# Altium schematic sheet 02 ECG Amplifier.schdoc
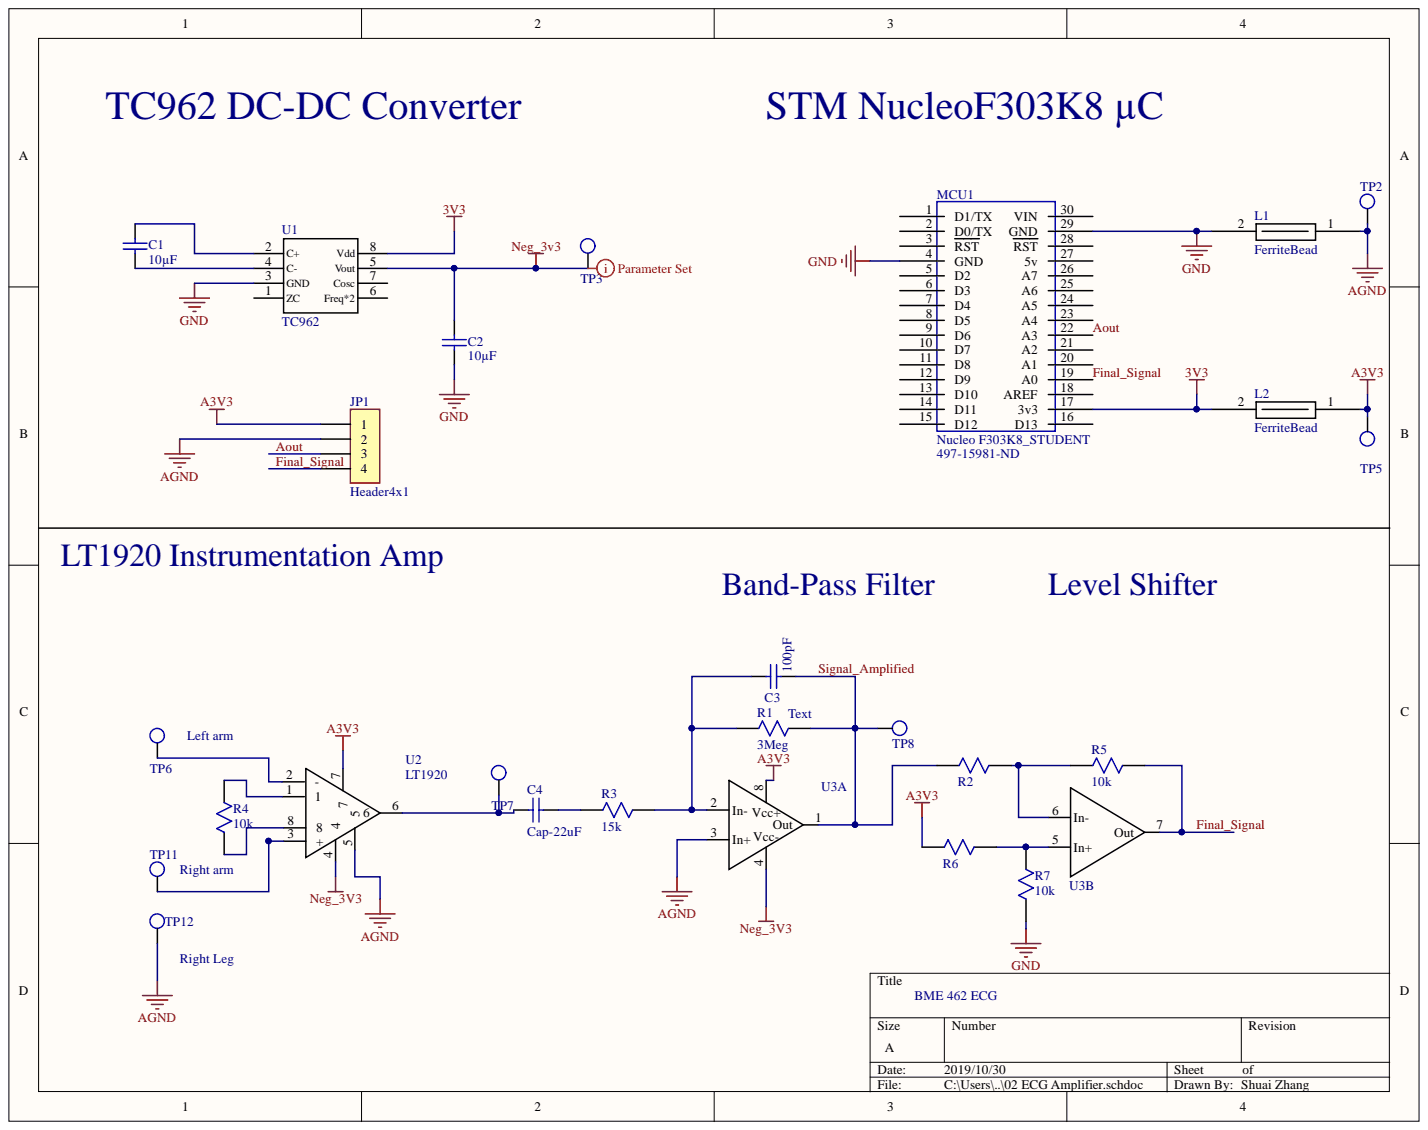
[diagram p0: 02 ECG Amplifier.schdoc]

## Parts
C1  Capacitance: 10µF  [Capacitor]  (hidden comment: Cap-10u)
C2  Capacitance: 10µF  [Capacitor]  (hidden comment: Cap-10u)
C3  Cap-100pF  [Capacitor]  (value not drawn)
C4  Cap-22uF  [Capacitor - Polarized]
JP1  Header4x1  [4 pin header]
L1  FerriteBead  [RESISTOR]
L2  FerriteBead  [RESISTOR]
MCU1  Nucleo F303K8_STUDENT  Supplier Part Number 1: 497-15981-ND  [Nucleo F303K8]
R1  Resistance (Ohms): 3Meg  [RESISTOR]  (hidden comment: Res-3M)
R2  20k  [RESISTOR]  (value not drawn)
R3  15k  [RESISTOR]
R4  Resistance (Ohms): 10k  [RESISTOR]  (hidden comment: Res-10k)
R5  Resistance (Ohms): 10k  [RESISTOR]  (hidden comment: Res-10k)
R6  20k  [RESISTOR]  (value not drawn)
R7  Resistance (Ohms): 10k  [RESISTOR]  (hidden comment: Res-10k)
TP2  TestPoint  [TestPoint]  (value not drawn)
TP3  TestPoint  [TestPoint]  (value not drawn)
TP5  TestPoint  [TestPoint]  (value not drawn)
TP6  TestPoint  [TestPoint]  (value not drawn)
TP7  TestPoint  [TestPoint]  (value not drawn)
TP8  TestPoint  [TestPoint]  (value not drawn)
TP11  TestPoint  [TestPoint]  (value not drawn)
TP12  TestPoint  [TestPoint]  (value not drawn)
U1  TC962  [DIP8]
U2  LT1920  [DIP8]
U3  TL072  [DIP8]  (value not drawn)  (parts A,B)

## Nets
3V3: L2.2 MCU1.17(3v3) U1.8(Vdd)
A3V3: JP1.1 L2.1 R6.1 TP5.1 U2.7 U3.8
AGND: JP1.2 L1.1 TP2.1 TP12.1 U2.5 U3.3(In+_Vcc-)
GND: C2.1 L1.2 MCU1.4(GND) MCU1.29(GND) R7.2 U1.3(GND)
Neg_3V3: C2.2 TP3.1 U1.5(Vout) U2.4 U3.4
Aout: JP1.3 MCU1.22(A3)
Final_Signal: JP1.4 MCU1.19(A0) R5.2 U3.7(Out)
Signal_Amplified: C3.1 R1.2 R2.1 TP8.1 U3.1(Out)
NetC1_1: C1.1 U1.4(C-)
NetC1_2: C1.2 U1.2(C+)
NetC3_2: C3.2 R1.1 R3.2 U3.2(In-_Vcc+)
NetC4_1: C4.1 TP7.1 U2.6
NetC4_2: C4.2 R3.1
NetR2_2: R2.2 R5.1 U3.6(In-)
NetR4_1: R4.1 U2.1
NetR4_2: R4.2 U2.8
NetR6_2: R6.2 R7.1 U3.5(In+)
NetTP6_1: TP6.1 U2.2(-)
NetTP11_1: TP11.1 U2.3(+)

Not connected: MCU1 1(D1/TX) 2(D0/TX) 3(RST) 5(D2) 6(D3) 7(D4) 8(D5) 9(D6) 10(D7) 11(D8) 12(D9) 13(D10) 14(D11) 15(D12) 16(D13) 18(AREF) 20(A1) 21(A2) 23(A4) 24(A5) 25(A6) 26(A7) 27(5v) 28(RST) 30(VIN); U1 1(ZC) 6(Freq*2) 7(Cosc)

## Library data of the parts
C1 C2 = Cap-10u: Manufacturer: TDK Corporation; Manufacturer Part Number: FK26X7R1C106K; Temperature Coefficient: X7R; Tolerance: ±10%; Voltage - Rated: 16V; Applications: General Purpose; Category: Capacitors; Component Kind: Standard; ComponentLink1Description: https://product.tdk.com/info/en/documents/catalog/leadmlcc_conventional_fk_en.pdf; ComponentLink1URL: https://product.tdk.com/info/en/documents/catalog/leadmlcc_conventional_fk_en.pdf; Features: -; Height - Seated (Max): 0.236" (6.00mm); Lead Spacing: 0.197" (5.00mm); Lead Style: Formed Leads - Kinked; Library Name: Schlib1.SchLib; Mounting Type: Through Hole; Operating Temperature: -55°C ~ 125°C; Package / Case: Radial; Packaging: Bulk; Pricing 1: 1=0.45, 10=0.319, 100=0.2101, 250=0.17896, 500=0.1556, 1000=0.13226, 5000=0.1167, 10000=0.10892, 25000=0.10503 (USD); Ratings: -; RoHS: RoHS Compliant; Size / Dimension: 0.217" L x 0.138" W (5.50mm x 3.50mm); Stock 1: 7933; Supplier 1: Digi-Key; Supplier Part Number 1: 445-8549-ND; Thickness (Max): -
L1 L2 = FerriteBead: Manufacturer: Laird-Signal Integrity Products; Manufacturer Part Number: 28L0138-10R-10; Current Rating (Max): 5A; DC Resistance (DCR) (Max): 10 mOhm; Impedance @ Frequency: 75 Ohm @ 100MHz; Number of Lines: 1; Category: Filters; Component Kind: Standard; ComponentLink1Description: http://media.digikey.com/pdf/Data%20Sheets/Laird%20Technologies/28L0138-10R-10_Dwg.pdf; ComponentLink1URL: http://media.digikey.com/pdf/Data%20Sheets/Laird%20Technologies/28L0138-10R-10_Dwg.pdf; Filter Type: -; Height (Max): -; Library Name: Schlib1.SchLib; Mounting Type: Through Hole; Operating Temperature: -; Package / Case: Axial; Packaging: Cut Tape (CT); Part Status: Active; Pricing 1: 1=0.1, 10=0.076, 25=0.0684, 50=0.05, 100=0.0433, 250=0.03416, 500=0.02884, 1000=0.02429 (USD); Ratings: -; RoHS: RoHS Compliant; Size / Dimension: 0.138" Dia x 0.175" L (3.51mm x 4.45mm); Stock 1: 25546; Supplier 1: Digi-Key; Supplier Part Number 1: 240-2438-1-ND
MCU1 = Nucleo F303K8_STUDENT: Nucleo F303K8; Manufacturer: Sullins Connector Solutions; Manufacturer Part Number: PPTC151LFBN-RC; Connector Type: Header; Contact Length - Post: 0.126" (3.20mm); Core Architecture: ARM; Current Rating: 3A; Evaluation Kit: Yes; Material Flammability Rating: UL94 V-0; Number of Bits: 32; Number of Positions: 15; Number of Positions Loaded: All; Pitch - Mating: 0.100" (2.54mm); Style: Board to Board; Voltage Rating: 250V; Applications: -; Category: Connectors, Interconnects; Component Kind: Standard; ComponentLink1Description: http://www.sullinscorp.com/drawings/78_P(N)PxCxxxLFBN-RC,_10492-H.pdf; ComponentLink1URL: http://www.sullinscorp.com/drawings/78_P(N)PxCxxxLFBN-RC,_10492-H.pdf; ComponentLink2Description: http://www.farnell.com/datasheets/2064195.pdf?src-supplier=Farnell; ComponentLink2URL: http://www.farnell.com/datasheets/2064195.pdf?src-supplier=Farnell; ComponentLink3Description: http://www.st.com/content/ccc/resource/technical/document/data_brief/b1/d8/13/d4/b0/b7/4b/6e/DM00214578.pdf/files/DM00214578.pdf/jcr:content/translations/en.DM00214578.pdf?src-supplier=Digi-Key; ComponentLink3URL: http://www.st.com/content/ccc/resource/technical/document/data_brief/b1/d8/13/d4/b0/b7/4b/6e/DM00214578.pdf/files/DM00214578.pdf/jcr:content/translations/en.DM00214578.pdf?src-supplier=Digi-Key; ComponentLink4Description: http://www.farnell.com/datasheets/1966773.pdf?src-supplier=Element14; ComponentLink4URL: http://www.farnell.com/datasheets/1966773.pdf?src-supplier=Element14; ComponentLink5Description: http://www.farnell.com/datasheets/2064195.pdf?src-supplier=Newark; ComponentLink5URL: http://www.farnell.com/datasheets/2064195.pdf?src-supplier=Newark; ComponentLink6Description: http://www.st.com/st-web-ui/static/active/en/resource/technical/document/user_manual/DM00116575.pdf?s_searchtype=keyword&src-supplier=Avnet+Asia; ComponentLink6URL: http://www.st.com/st-web-ui/static/active/en/resource/technical/document/user_manual/DM00116575.pdf?s_searchtype=keyword&src-supplier=Avnet+Asia; ComponentLink7Description: http://www.st.com/st-web-ui/static/active/en/resource/technical/document/user_manual/DM00116575.pdf?s_searchtype=keyword&src-supplier=Avnet; ComponentLink7URL: http://www.st.com/st-web-ui/static/active/en/resource/technical/document/user_manual/DM00116575.pdf?s_searchtype=keyword&src-supplier=Avnet; Contact Finish - Mating: Tin; Contact Finish - Post: Tin; Contact Finish Thickness - Mating: -; Contact Finish Thickness - Post: -; Contact Material: Phosphor Bronze; Contact Shape: Square; Contact Type: Female Socket; Fastening Type: Push-Pull; Features: -; Ingress Protection: -; Insulation Color: Black; Insulation Height: 0.335" (8.51mm); Insulation Material: Polyester; Lead Free: Lead Free; Library Name: Schlib1.SchLib; Mated Stacking Heights: -; Mounting Type: Through Hole; Number of Rows: 1; Operating Temperature: -40°C ~ 105°C; Packaging: Bulk; Part Status: Active; Pricing 1: 1=10.99000 (USD); REACH SVHC: No SVHC; RoHS: RoHS Compliant; Row Spacing - Mating: -; Stock 1: 117; Supplier 1: Digi-Key; Termination: Solder
R1 = Res-3M: Manufacturer: Stackpole Electronics Inc.; Manufacturer Part Number: CF14JT30K0; Power (Watts): 0.25W, 1/4W; Temperature Coefficient: 0/ -500ppm/°C; Tolerance: ±5%; Category: Resistors; Component Kind: Standard; ComponentLink1Description: https://www.seielect.com/Catalog/SEI-CF_CFM.pdf; ComponentLink1URL: https://www.seielect.com/Catalog/SEI-CF_CFM.pdf; Composition: Carbon Film; Failure Rate: -; Features: Flame Retardant Coating, Safety; Height - Seated (Max): -; Library Name: Schlib1.SchLib; Operating Temperature: -55°C ~ 155°C; Package / Case: Axial; Packaging: Cut Tape (CT); Part Status: Active; RoHS: RoHS Compliant; Size / Dimension: 0.091" Dia x 0.236" L (2.30mm x 6.00mm); Supplier 1: Arrow; Supplier Device Package: Axial
R2 R6 = Res-20k: Component Kind: Standard; Library Name: Schlib1.SchLib; Supplier 1: Arrow
R3 = Res-15k: Component Kind: Standard; Library Name: Schlib1.SchLib; Supplier 1: Arrow
R4 R5 R7 = Res-10k: Manufacturer: Stackpole Electronics Inc.; Manufacturer Part Number: CF14JT10K0; Power (Watts): 0.25W, 1/4W; Temperature Coefficient: 0/ -500ppm/°C; Tolerance: ±5%; Category: Resistors; Component Kind: Standard; ComponentLink1Description: http://www.seielect.com/catalog/SEI-CF_CFM.pdf; ComponentLink1URL: http://www.seielect.com/catalog/SEI-CF_CFM.pdf; Composition: Carbon Film; Features: Flame Retardant Coating, Safety; Height: -; Library Name: Schlib1.SchLib; Number of Terminations: 2; Operating Temperature: -55°C ~ 155°C; Package / Case: Axial; Packaging: Cut Tape (CT); Pricing 1: 1=0.1, 10=0.04, 50=0.022, 100=0.0162, 250=0.01236, 500=0.0099, 1000=0.00729, 2500=0.00632 (USD); RoHS: RoHS Compliant; Size / Dimension: 0.091" Dia x 0.256" L (2.30mm x 6.50mm); Stock 1: 1509635; Supplier 1: Digi-Key; Supplier Device Package: Axial; Supplier Part Number 1: CF14JT10K0CT-ND
TP2 TP3 TP5-TP8 TP11 TP12 = TestPoint: Usage: DNP; Component Kind: Standard; Library Name: Schlib1.SchLib
U3 = TL072: Manufacturer: Texas Instruments; Manufacturer Part Number: TL072IP; Amplifier Type: J-FET; Current - Supply: 1.4mA; Number of Circuits: 2; Slew Rate: 13 V/µs; Voltage - Supply, Single/Dual (±): 7 V ~ 36 V, ±3.5 V ~ 18 V; -3db Bandwidth: -; Category: Integrated Circuits (ICs); Component Kind: Standard; ComponentLink1Description: http://www.ti.com/lit/ds/symlink/tl071a.pdf; ComponentLink1URL: http://www.ti.com/lit/ds/symlink/tl071a.pdf; Current - Output / Channel: -; Library Name: Schlib1.SchLib; Mounting Type: Through Hole; Operating Temperature: -40°C ~ 85°C; Output Type: -; Package / Case: 8-DIP (0.300", 7.62mm); Packaging: Tube; Part Status: Active; Pricing 1: 1=0.69, 10=0.607, 100=0.4655, 500=0.368, 1000=0.2944 (USD); RoHS: RoHS Compliant; Stock 1: 2537; Supplier 1: Digi-Key; Supplier Device Package: 8-PDIP; Supplier Part Number 1: 296-14997-5-ND
C3 = Cap-100pF: Component Kind: Standard; Library Name: Schlib1.SchLib; Supplier 1: Digi-Key
C4 = Cap-22uF: Component Kind: Standard; Library Name: Schlib1.SchLib
JP1 = Header4x1: Component Kind: Standard; Library Name: Schlib1.SchLib
U1 = TC962: Component Kind: Standard; Library Name: Schlib1.SchLib; Supplier 1: Digi-Key; Supplier Part Number 1: TC962CPA-ND
U2 = LT1920: Component Kind: Standard; Library Name: Schlib1.SchLib; Supplier 1: Digi-Key; Supplier Part Number 1: LT1920CN8#PBF-ND
